# Altium schematic sheet RC96_sht2.SchDoc
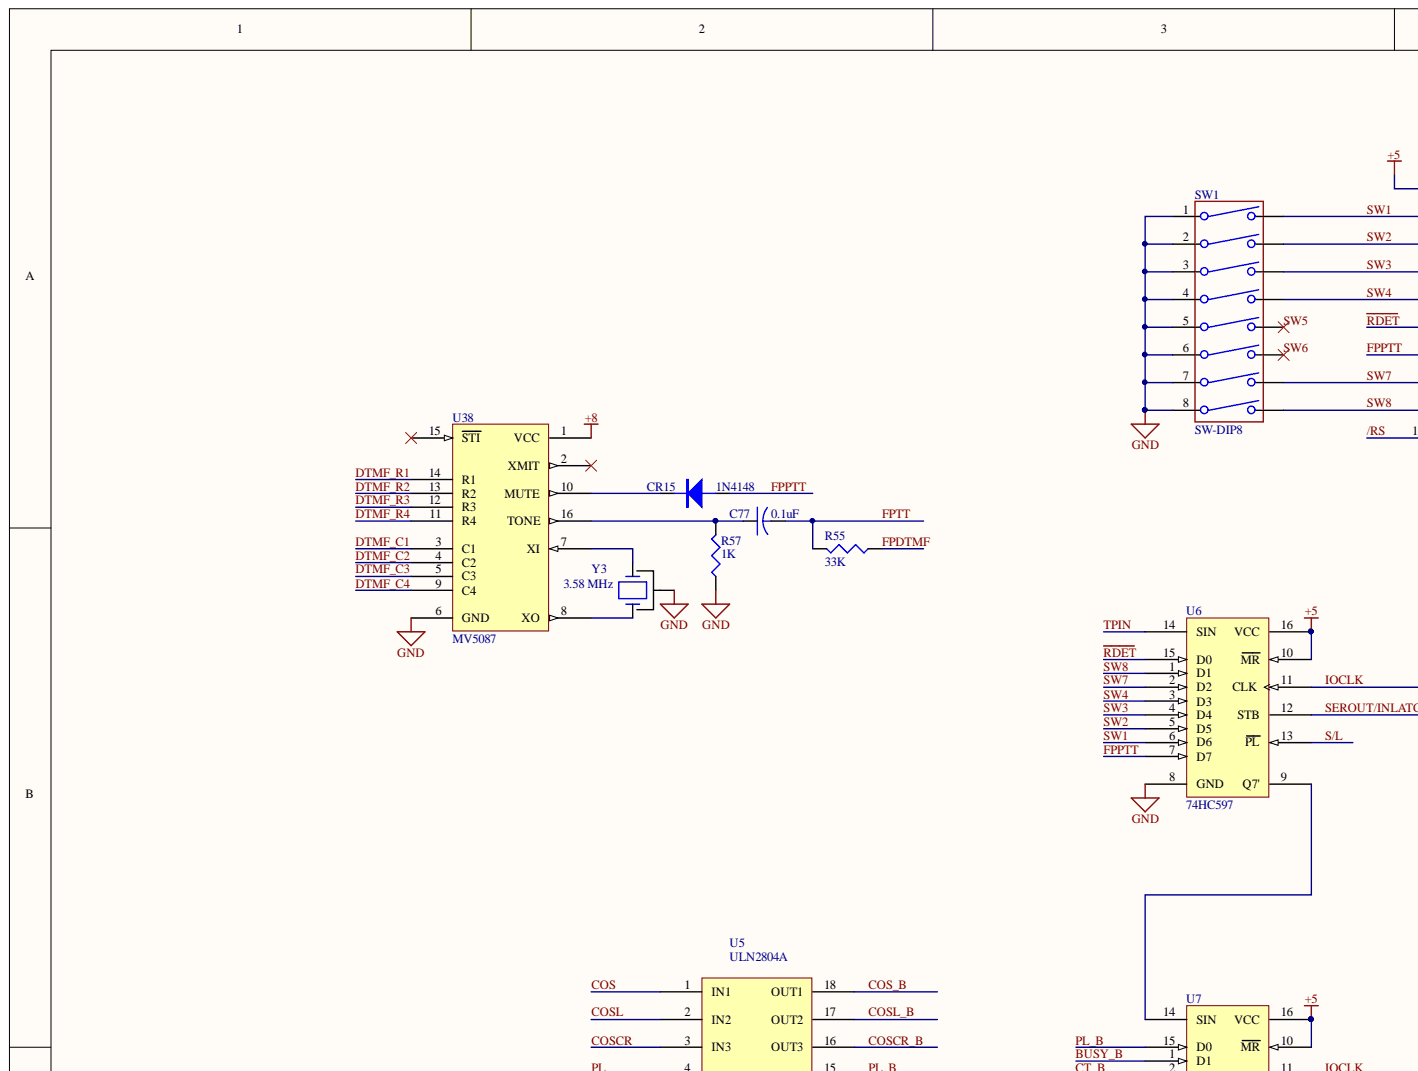
[diagram p0: RC96_sht2.SchDoc, top left]
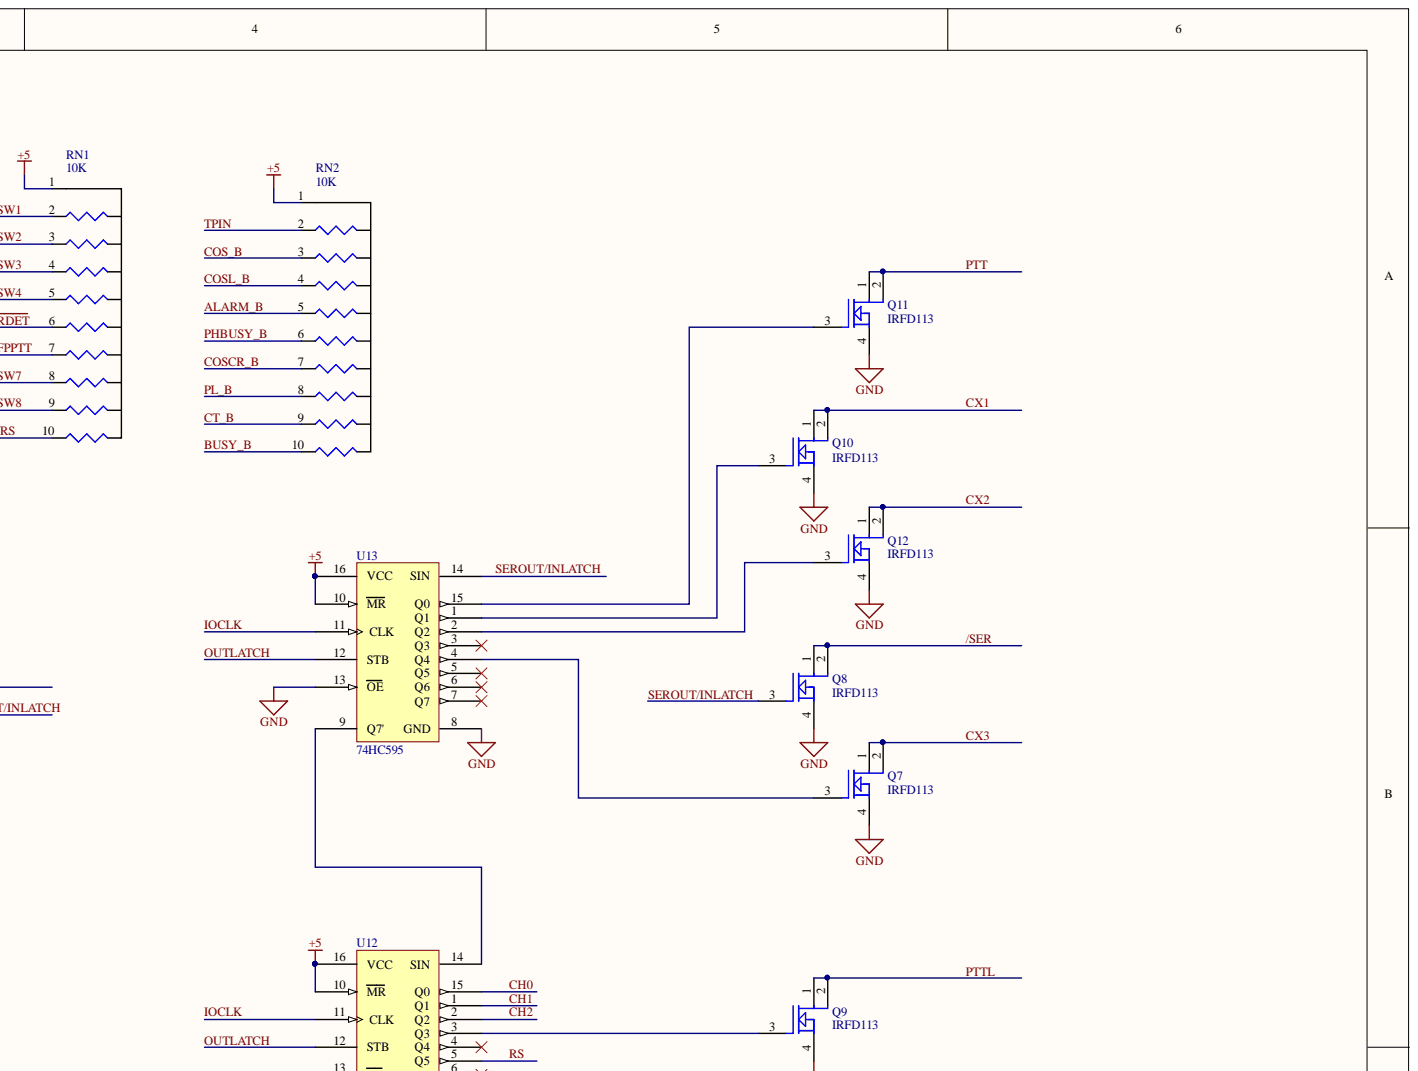
[diagram p1: RC96_sht2.SchDoc, top right]
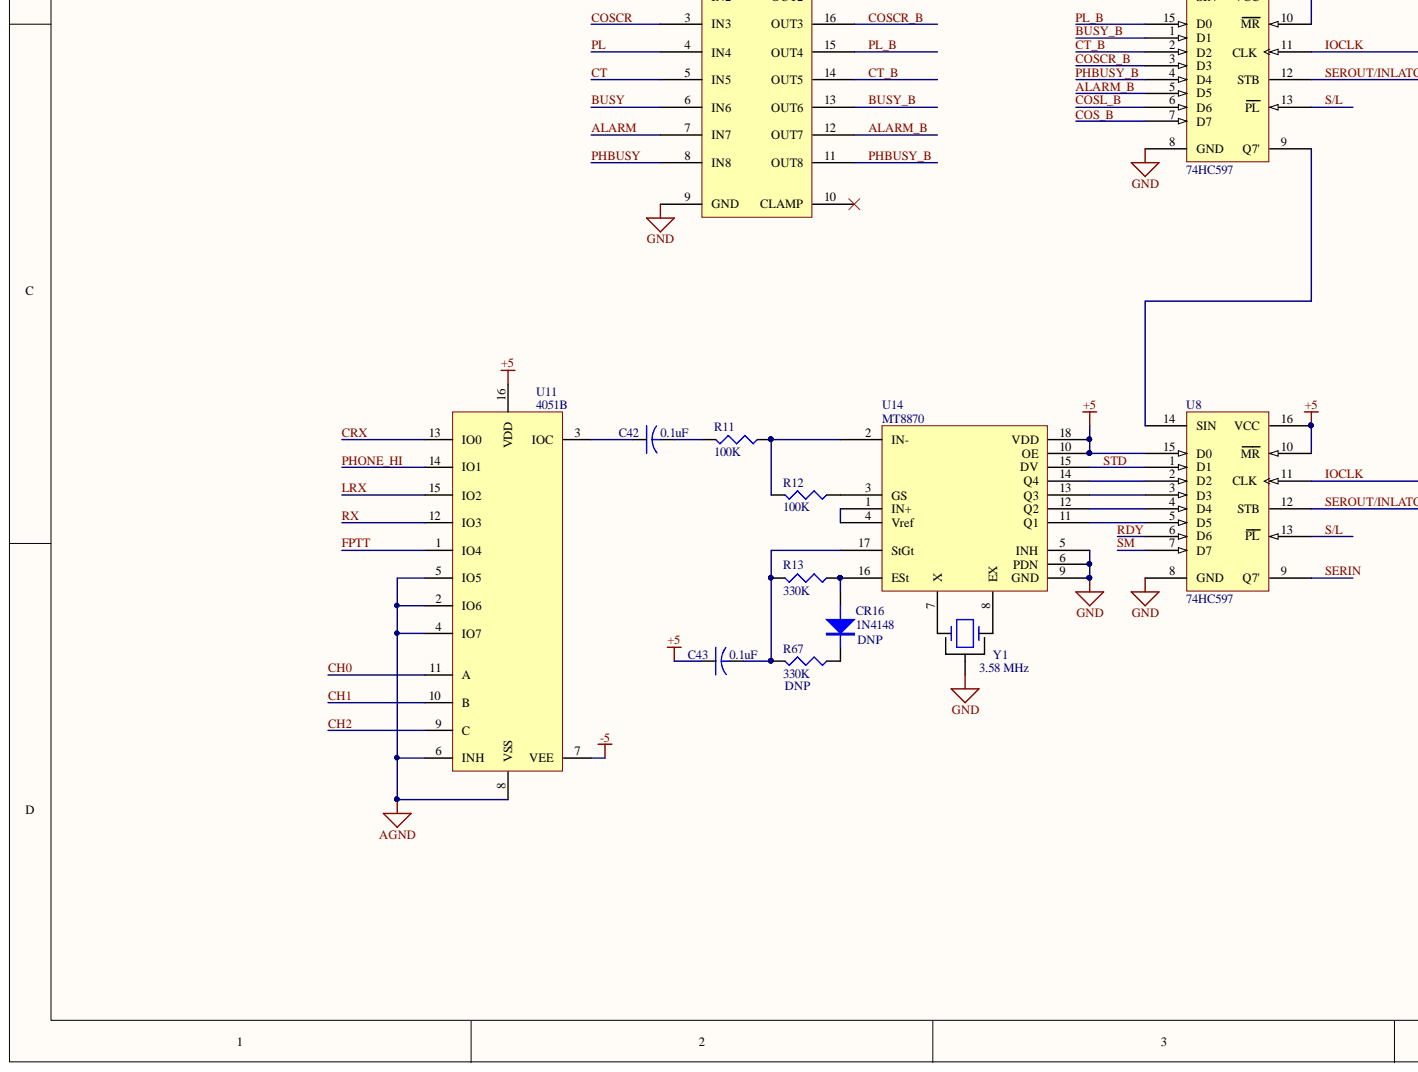
[diagram p2: RC96_sht2.SchDoc, bottom left]
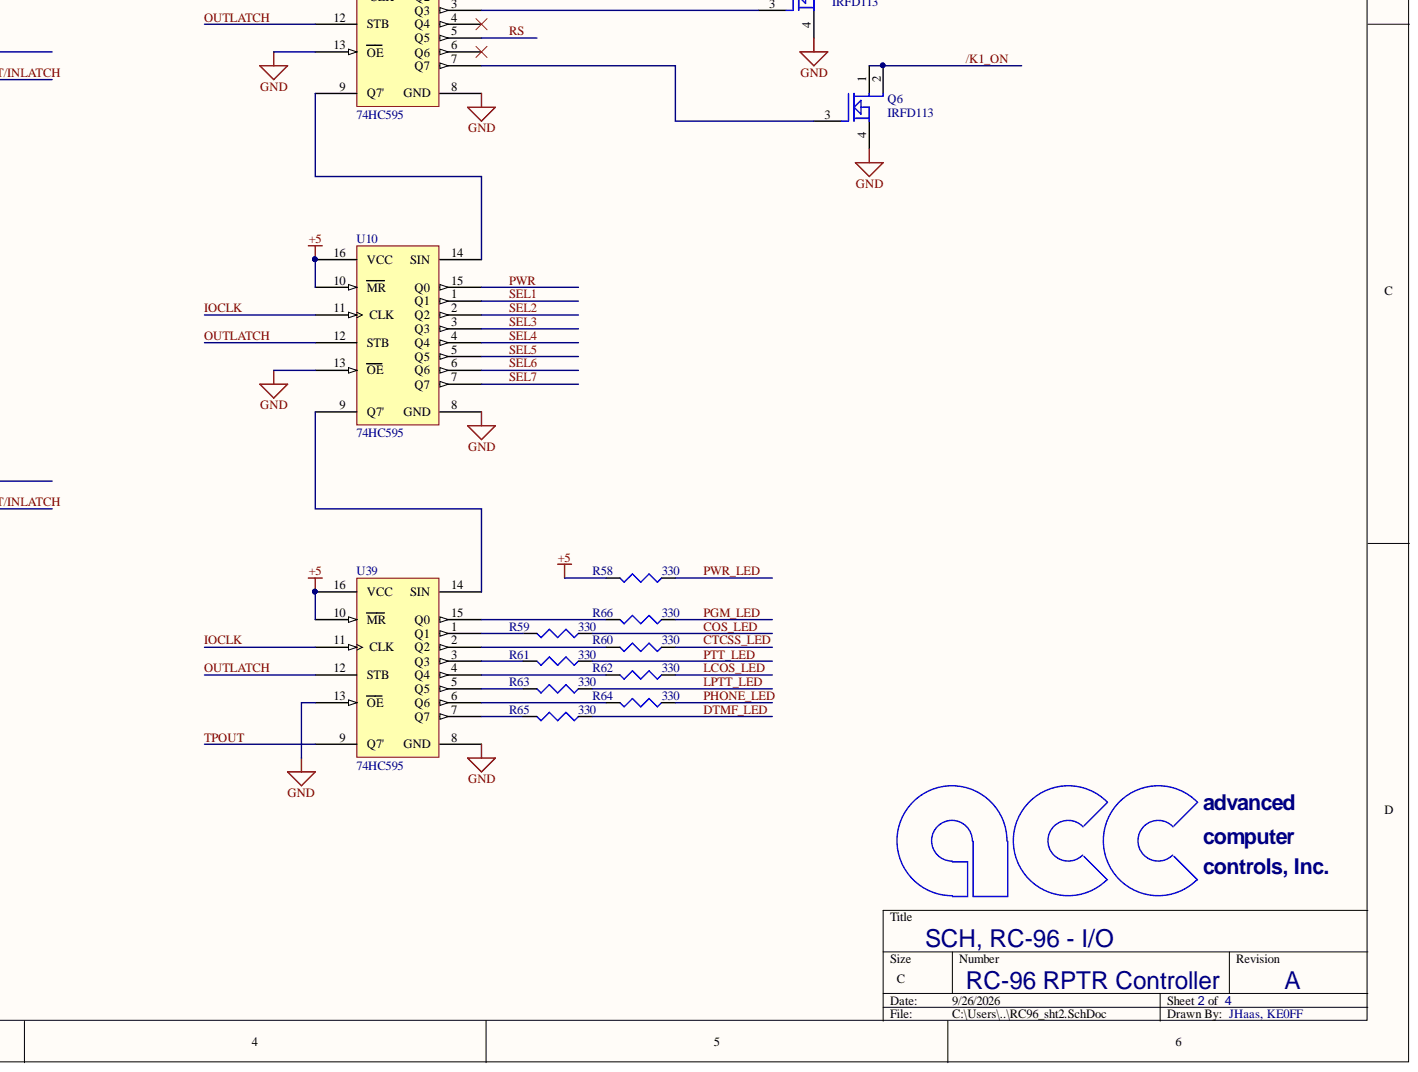
[diagram p3: RC96_sht2.SchDoc, bottom right]

## Parts
C42  0.1uF  [RAD2]
C43  0.1uF  [RAD2]
C77  0.1uF  [RAD2]
CR15  1N4148  [AXIAL3]
CR16  1N4148  [AXIAL3]
Q6  IRFD113  [DIP4]
Q7  IRFD113  [DIP4]
Q8  IRFD113  [DIP4]
Q9  IRFD113  [DIP4]
Q10  IRFD113  [DIP4]
Q11  IRFD113  [DIP4]
Q12  IRFD113  [DIP4]
R11  100K  [AXIAL4]
R12  100K  [AXIAL4]
R13  330K  [AXIAL4]
R55  33K  [AXIAL4]
R57  1K  [AXIAL4]
R58  330  [AXIAL4]
R59  330  [AXIAL4]
R60  330  [AXIAL4]
R61  330  [AXIAL4]
R62  330  [AXIAL4]
R63  330  [AXIAL4]
R64  330  [AXIAL4]
R65  330  [AXIAL4]
R66  330  [AXIAL4]
R67  330K  [AXIAL4]
RN1  10K  [SIP10]
RN2  10K  [SIP10]
SW1  SW-DIP8  [SWDIP8]
U5  ULN2804A  [DIP18]
U6  74HC597  [DIP16]
U7  74HC597  [DIP16]
U8  74HC597  [DIP16]
U10  74HC595  [DIP16]
U11  4051B  [DIP16]
U12  74HC595  [DIP16]
U13  74HC595  [DIP16]
U14  MT8870  [DIP18]
U38  MV5087  [DIP16]
U39  74HC595  [DIP16]
Y1  3.58 MHz  [HC-49/U]
Y3  3.58 MHz  [HC-49/U]

## Nets
+5: C43.1 R58.2 RN1.1 RN2.1 U6.10(MR) U6.16(VCC) U7.10(MR) U7.16(VCC) U8.10(MR) U8.15(D0) U8.16(VCC) U10.10(MR) U10.16(VCC) U11.16(VDD) U12.10(MR) U12.16(VCC) U13.10(MR) U13.16(VCC) U14.10(OE) U14.18(VDD) U39.10(MR) U39.16(VCC)
+8: U38.1(VCC)
-5: U11.7(VEE)
AGND: U11.2(IO6) U11.4(IO7) U11.5(IO5) U11.6(INH) U11.8(VSS)
GND: Q6.4 Q7.4 Q8.4 Q9.4 Q10.4 Q11.4 Q12.4 R57.1 SW1.1 SW1.2 SW1.3 SW1.4 SW1.5 SW1.6 SW1.7 SW1.8 U5.9(GND) U6.8(GND) U7.8(GND) U8.8(GND) U10.8(GND) U10.13(OE) U12.8(GND) U12.13(OE) U13.8(GND) U13.13(OE) U14.5(INH) U14.6(PDN) U14.9(GND) U38.6(GND) U38.10(MUTE) U39.8(GND) U39.13(OE) Y1.3 Y3.3
VCC: U38.20
/K1_ON: Q6.1 Q6.2
/RS: RN1.10
/SER: Q8.1 Q8.2
ALARM: U5.7(IN7)
ALARM_B: RN2.5 U5.12(OUT7) U7.5(D5)
BUSY: U5.6(IN6)
BUSY_B: RN2.10 U5.13(OUT6) U7.1(D1)
CH0: U11.11(A) U12.15(Q0)
CH1: U11.10(B) U12.1(Q1)
CH2: U11.9(C) U12.2(Q2)
COS: U5.1(IN1)
COS_B: RN2.3 U5.18(OUT1) U7.7(D7)
COS_LED: R59.1
COSCR: U5.3(IN3)
COSCR_B: RN2.7 U5.16(OUT3) U7.3(D3)
COSL: U5.2(IN2)
COSL_B: RN2.4 U5.17(OUT2) U7.6(D6)
CRX: U11.13(IO0)
CT: U5.5(IN5)
CT_B: RN2.9 U5.14(OUT5) U7.2(D2)
CTCSS_LED: R60.1
CX1: Q10.1 Q10.2
CX2: Q12.1 Q12.2
CX3: Q7.1 Q7.2
DTMF_C1: U38.3(C1)
DTMF_C2: U38.4(C2)
DTMF_C3: U38.5(C3)
DTMF_C4: U38.9(C4)
DTMF_LED: R65.1
DTMF_R1: U38.14(R1)
DTMF_R2: U38.13(R2)
DTMF_R3: U38.12(R3)
DTMF_R4: U38.11(R4)
FPDTMF: R55.1
FPPTT: CR15.2 RN1.7 U6.7(D7)
FPTT: C77.2 R55.2 U11.1(IO4)
IOCLK: U6.11(CLK) U7.11(CLK) U8.11(CLK) U10.11(CLK) U12.11(CLK) U13.11(CLK) U39.11(CLK)
LCOS_LED: R62.1
LPTT_LED: R63.1
LRX: U11.15(IO2)
OUTLATCH: U10.12(STB) U12.12(STB) U13.12(STB) U39.12(STB)
PGM_LED: R66.1
PHBUSY: U5.8(IN8)
PHBUSY_B: RN2.6 U5.11(OUT8) U7.4(D4)
PHONE_HI: U11.14(IO1)
PHONE_LED: R64.1
PL: U5.4(IN4)
PL_B: RN2.8 U5.15(OUT4) U7.15(D0)
PTT: Q11.1 Q11.2
PTT_LED: R61.1
PTTL: Q9.1 Q9.2
PWR: U10.15(Q0)
PWR_LED: R58.1
~{RDET}: RN1.6 U6.15(D0)
RDY: U8.6(D6)
RS: U12.5(Q5)
RX: U11.12(IO3)
S/L: U6.13(PL) U7.13(PL) U8.13(PL)
SEL1: U10.1(Q1)
SEL2: U10.2(Q2)
SEL3: U10.3(Q3)
SEL4: U10.4(Q4)
SEL5: U10.5(Q5)
SEL6: U10.6(Q6)
SEL7: U10.7(Q7)
SERIN: U8.9(Q7')
SEROUT/INLATCH: Q8.3 U6.12(STB) U7.12(STB) U8.12(STB) U13.14(SIN)
SM: U8.7(D7)
STD: U8.1(D1) U14.15(DV)
SW1: RN1.2 SW1.16 U6.6(D6)
SW2: RN1.3 SW1.15 U6.5(D5)
SW3: RN1.4 SW1.14 U6.4(D4)
SW4: RN1.5 SW1.13 U6.3(D3)
SW5: SW1.12
SW6: SW1.11
SW7: RN1.8 SW1.10 U6.2(D2)
SW8: RN1.9 SW1.9 U6.1(D1)
TPIN: RN2.2 U6.14(SIN)
TPOUT: U39.9(Q7')
NetC42_1: C42.1 U11.3(IOC)
NetC42_2: C42.2 R11.2
NetC43_2: C43.2 R13.2 R67.2 U14.17(StGt)
NetC77_1: C77.1 R57.2 U38.16(TONE)
NetCR15_1: CR15.1 U38.10(MUTE)
NetCR16_1: CR16.1 R67.1
NetCR16_2: CR16.2 R13.1 U14.16(ESt)
NetQ6_3: Q6.3 U12.7(Q7)
NetQ7_3: Q7.3 U13.4(Q4)
NetQ9_3: Q9.3 U12.3(Q3)
NetQ10_3: Q10.3 U13.1(Q1)
NetQ11_3: Q11.3 U13.15(Q0)
NetQ12_3: Q12.3 U13.2(Q2)
NetR11_1: R11.1 R12.2 U14.2(IN-)
NetR12_1: R12.1 U14.3(GS)
NetR59_2: R59.2 U39.1(Q1)
NetR60_2: R60.2 U39.2(Q2)
NetR61_2: R61.2 U39.3(Q3)
NetR62_2: R62.2 U39.4(Q4)
NetR63_2: R63.2 U39.5(Q5)
NetR64_2: R64.2 U39.6(Q6)
NetR65_2: R65.2 U39.7(Q7)
NetR66_2: R66.2 U39.15(Q0)
NetU6_9: U6.9(Q7') U7.14(SIN)
NetU7_9: U7.9(Q7') U8.14(SIN)
NetU8_2: U8.2(D2) U14.14(Q4)
NetU8_3: U8.3(D3) U14.13(Q3)
NetU8_4: U8.4(D4) U14.12(Q2)
NetU8_5: U8.5(D5) U14.11(Q1)
NetU10_9: U10.9(Q7') U39.14(SIN)
NetU10_14: U10.14(SIN) U12.9(Q7')
NetU12_14: U12.14(SIN) U13.9(Q7')
NetU14_1: U14.1(IN+) U14.4(Vref)
NetU14_7: U14.7(X) Y1.1
NetU14_8: U14.8(EX) Y1.2
NetU38_7: U38.7(XI) Y3.2
NetU38_8: U38.8(XO) Y3.1

Not connected: U5 10(CLAMP); U12 4(Q4) 6(Q6); U13 3(Q3) 5(Q5) 6(Q6) 7(Q7); U38 2(XMIT) 15(STI)

## Library data of the parts
C42 C43 C77 = CAP 0805: CAP, TANT, 0805, 50V, 1uF; ALT-MFR: -; ALT-MPN: -; Component Kind: Standard; DNP: -; MFR: -; MPN: -; Library Name: Galaxy SCH-jem-1.08.SchLib
CR15 CR16 = DIODE-AXIAL: Diode; ALT-MPN: -; Component Kind: Standard; MFR: -; MPN: -; NOTES: -; NOTES2: -; PRI-MPN: -; Library Name: Galaxy SCH-jem-1.08.SchLib
  CR15: DNP: -
  CR16: DNP: DNP
Q6-Q12 = MOSFET N_1: TR, FET, DIP4, N-CH; ALT-MPN: -; Component Kind: Standard; DNP: -; MFR: -; MPN: IRFD113; NOTES: -; NOTES2: -; PRI-MPN: -; Library Name: Galaxy SCH-jem-1.08.SchLib
R11-R13 R55 R57-R67 = R0805: RES, CHP, 0201, 1%, 4.7K; ALT-MPN: -; Component Kind: Standard; MFR: -; MPN: -; NOTES: -; NOTES2: -; PRI-MPN: -; Library Name: Galaxy SCH-jem-1.08.SchLib
  R11-R13 R55 R57-R66: DNP: -
  R67: DNP: DNP
RN1 RN2 = RP9: RES, NET, SIP, 9RES; ALT-MPN: -; Component Kind: Standard; DNP: -; MFR: -; MPN: -; NOTES: -; NOTES2: -; PRI-MPN: -; Library Name: Galaxy SCH-jem-1.08.SchLib
SW1 = SW-DIP8: SW, DIP, PCB, 8POS; ALT-MPN: -; Component Kind: Standard; DNP: -; MFR: -; MPN: -; NOTES: -; NOTES2: -; PRI-MPN: -; Library Name: Galaxy SCH-jem-1.08.SchLib
U6-U8 = 74HC597: IC, LGC, SR, PIN, OCTL; MFR: TI; MPN: CD74HC597EE4; ALT-MPN: -; Component Kind: Standard; DNP: -; NOTES: -; NOTES2: -; PRI-MPN: -; Library Name: Galaxy SCH-jem-1.08.SchLib
U10 U12 U13 U39 = 74HC595: IC, LGC, SR, PIN, OCTL; MFR: TI; MPN: CD74HC595E; ALT-MPN: -; Component Kind: Standard; DNP: -; NOTES: -; NOTES2: -; PRI-MPN: -; Library Name: Galaxy SCH-jem-1.08.SchLib
U11 = 4051: Component Kind: Standard
U14 = MT8870: IC, RCVR, DTMF; MFR: Mitel; MPN: M8870; ALT-MPN: -; Component Kind: Standard; DNP: -; NOTES: -; NOTES2: -; PRI-MPN: -; Library Name: Galaxy SCH-jem-1.08.SchLib
U38 = MV5087: IC, GEN, DTMF, 1:8; MFR: GEC Plessey; ALT-MPN: -; Component Kind: Standard; DNP: -; MPN: MV5087; NOTES: -; NOTES2: -; PRI-MPN: -; Library Name: Galaxy SCH-jem-1.08.SchLib
Y1 Y3 = CRYSTAL-3PIN_SH: XTAL, 3-PIN (SH); ALT-MPN: -; Component Kind: Standard; DNP: -; MFR: -; MPN: -; NOTES: -; NOTES2: -; PRI-MPN: -; Library Name: Galaxy SCH-jem-1.08.SchLib
U5 = ULN2804A: Component Kind: Standard
